# Altium schematic sheet GATE_DRIVER.SchDoc(GATE_DRIVER)
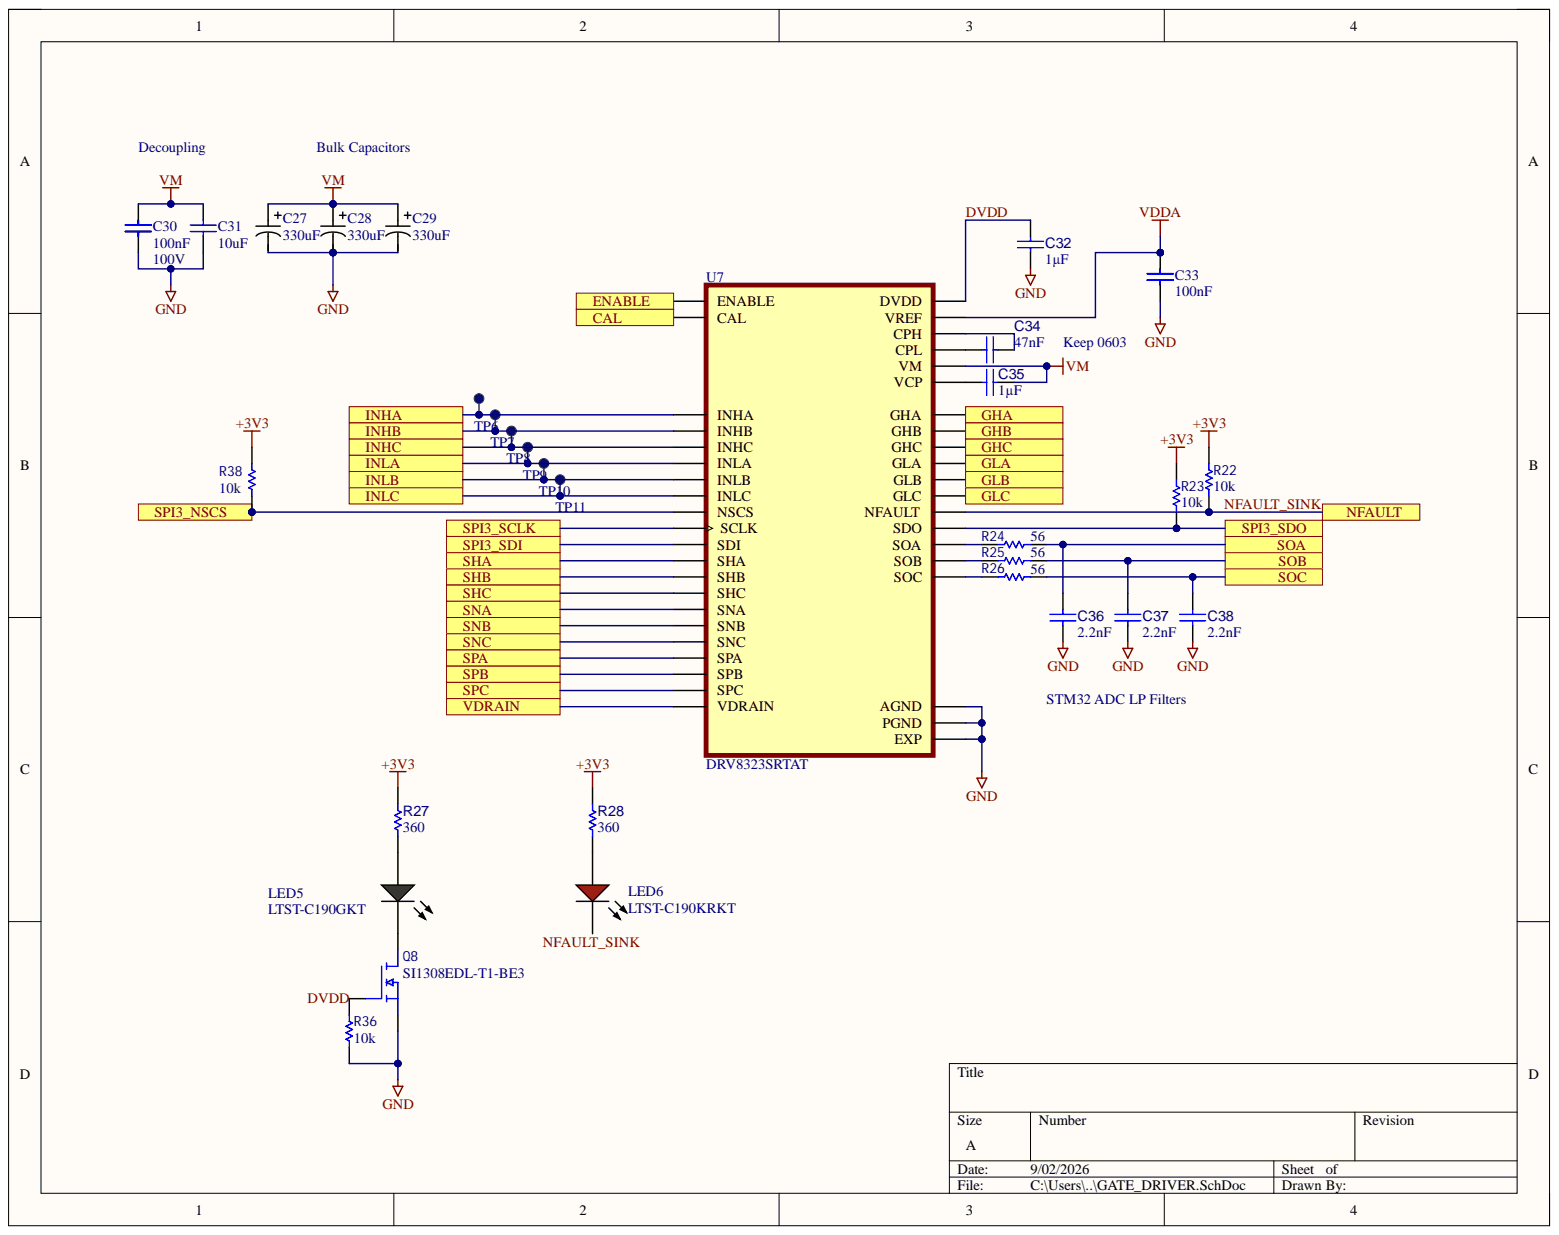
[diagram p0: GATE_DRIVER.SchDoc]

## Parts
C27  330uF  [CAP_PY-13x20_KEM]
C28  330uF  [CAP_PY-13x20_KEM]
C29  330uF  [CAP_PY-13x20_KEM]
C30  100nF  Voltage Rating: 100V  [0603_Capacitor]
C31  10uF  [CAP_CL32B_SAM]
C32  1µF  [0603_Capacitor]
C33  100nF  [C-0402]
C34  47nF  [0603_Capacitor]
C35  1µF  [0603_Capacitor]
C36  2.2nF  [C-0402]
C37  2.2nF  [C-0402]
C38  2.2nF  [C-0402]
LED5  LTST-C190GKT  [LTST-C190GKT_V]
LED6  LTST-C190KRKT  [LTST-C191KRKT_V]
Q8  value SI1308EDL-T1-BE3  Manufacturer PN: SI1308EDL-T1-BE3  [SC70_AOS]  (hidden comment: Transistor MOSFET SI1308EDL-T1-BE3)
R22  value 10k  [R-0402]  (hidden comment: Resistor 10k)
R23  value 10k  [R-0402]  (hidden comment: Resistor 10k)
R24  value 56  [R-0402]  (hidden comment: Resistor 56)
R25  value 56  [R-0402]  (hidden comment: Resistor 56)
R26  value 56  [R-0402]  (hidden comment: Resistor 56)
R27  360  [R-0402]
R28  360  [R-0402]
R36  value 10k  [R-0402]  (hidden comment: Resistor 10k)
R38  value 10k  [R-0402]  (hidden comment: Resistor 10k)
TP6  Test Pad  [Test_Pad]  (value not drawn)  (no BOM)
TP7  Test Pad  [Test_Pad]  (value not drawn)  (no BOM)
TP8  Test Pad  [Test_Pad]  (value not drawn)  (no BOM)
TP9  Test Pad  [Test_Pad]  (value not drawn)  (no BOM)
TP10  Test Pad  [Test_Pad]  (value not drawn)  (no BOM)
TP11  Test Pad  [Test_Pad]  (value not drawn)  (no BOM)
U7  DRV8323SRTAT  [QFN50P600X600X80-41N415X415]

## Ports
CAL, ENABLE, GHA, GHB, GHC, GLA, GLB, GLC, INHA, INHB, INHC, INLA, INLB, INLC, NFAULT, SHA, SHB, SHC, SNA, SNB, SNC, SOA, SOB, SOC, SPA, SPB, SPC, SPI3_NSCS, SPI3_SCLK, SPI3_SDI, SPI3_SDO, VDRAIN

## Nets
+3V3: R22.2 R23.2 R27.2 R28.2 R38.1
GND: C27.2 C28.2 C29.2 C30.2 C31.1 C32.2 C33.2 C36.1 C37.1 C38.1 Q8.2 R36.2 U7.32(AGND) U7.40(PGND) U7.41(EXP)
VDDA: C33.1 U7.24(VREF)
VM: C27.1 C28.1 C29.1 C30.1 C31.2 C35.2 U7.4(VM)
CAL: U7.31(CAL) ; port CAL
DVDD: C32.1 Q8.1 R36.1 U7.33(DVDD)
ENABLE: U7.30(ENABLE) ; port ENABLE
GHA: U7.6(GHA) ; port GHA
GHB: U7.15(GHB) ; port GHB
GHC: U7.16(GHC) ; port GHC
GLA: U7.8(GLA) ; port GLA
GLB: U7.13(GLB) ; port GLB
GLC: U7.18(GLC) ; port GLC
INHA: TP6.TP? U7.34(INHA) ; port INHA
INHB: TP7.TP? U7.36(INHB) ; port INHB
INHC: TP8.TP? U7.38(INHC) ; port INHC
INLA: TP9.TP? U7.35(INLA) ; port INLA
INLB: TP10.TP? U7.37(INLB) ; port INLB
INLC: TP11.TP? U7.39(INLC) ; port INLC
NFAULT_SINK: LED6.2 R22.1 U7.25(NFAULT) ; port NFAULT
SHA: U7.7(SHA) ; port SHA
SHB: U7.14(SHB) ; port SHB
SHC: U7.17(SHC) ; port SHC
SNA: U7.10(SNA) ; port SNA
SNB: U7.11(SNB) ; port SNB
SNC: U7.20(SNC) ; port SNC
SOA: C36.2 R24.2 ; port SOA
SOB: C37.2 R25.2 ; port SOB
SOC: C38.2 R26.2 ; port SOC
SPA: U7.9(SPA) ; port SPA
SPB: U7.12(SPB) ; port SPB
SPC: U7.19(SPC) ; port SPC
SPI3_NSCS: R38.2 U7.29(NSCS) ; port SPI3_NSCS
SPI3_SCLK: U7.28(SCLK) ; port SPI3_SCLK
SPI3_SDI: U7.27(SDI) ; port SPI3_SDI
SPI3_SDO: R23.1 U7.26(SDO) ; port SPI3_SDO
VDRAIN: U7.5(VDRAIN) ; port VDRAIN
NetC34_1: C34.1 U7.1(CPL)
NetC34_2: C34.2 U7.2(CPH)
NetC35_1: C35.1 U7.3(VCP)
NetLED5_1: LED5.1 R27.1
NetLED5_2: LED5.2 Q8.3
NetLED6_1: LED6.1 R28.1
NetR24_1: R24.1 U7.23(SOA)
NetR25_1: R25.1 U7.22(SOB)
NetR26_1: R26.1 U7.21(SOC)

## Library data of the parts
C27-C29 = CMP-001-00244-2: 330 µF 63 V Aluminum - Polymer Capacitors Radial, Can 42mOhm 2000 Hrs @ 125°C; Manufacturer: KEMET; Manufacturer PN: A759PY337M1JAAE042; ESR: 42m; Tolerance: 20%; Type: Capacitor Aluminum Polymer; Voltage Rating: 63V; Component Kind: Standard; ComponentLink1Description: Datasheet; ComponentLink1URL: https://yageogroup.com/content/datasheet/asset/file/KEM_A4072_A759; Library Name: BLDC_Symbols.SchLib; Package: TH; Supplier 1: Digikey; Supplier Part Number 1: 399-16573-ND; Value: 330uF
C30 = CAP, SMD, 0.1uF 0603 100V X7R: 0.1 µF ±10% 100V Ceramic Capacitor X7R 0603 (1608 Metric); Manufacturer: Walsin Technology Corporation; Manufacturer PN: 0603B104K101CT; Component Kind: Standard; ComponentLink1Description: Datasheet; ComponentLink1URL: https://www.mouser.com/datasheet/3/508/1/KEM_C1002_X7R_SMD.pdf; Library Name: BLDC_Symbols.SCHLIB; Package: 0603; Supplier 1: Digikey; Supplier Part Number 1: 1292-1392-1-ND; Tolerance: 10%; Type: Capacitor X7R; Value: 100nF
C31 = CMP-001-00241-2: 10 µF ±10% 63V Ceramic Capacitor X7R 1210 (3225 Metric); Manufacturer: Samsung Electro-Mechanics; Manufacturer PN: CL32B106KMVNNWE; Component Kind: Standard; ComponentLink1Description: Datasheet; ComponentLink1URL: https://mm.digikey.com/Volume0/opasdata/d220001/medias/docus/2124/CL32B106KMVNNWE_Spec.pdf; Library Name: BLDC_Symbols.SchLib; Package: 1210; Supplier 1: Digikey; Supplier Part Number 1: 1276-7053-1-ND; Tolerance: 10%; Type: Capacitor X7R; Value: 10uF; Voltage Rating: 63V
C32 C35 = CMP-001-00209-4: 1 µF ±10% 25V Ceramic Capacitor X7R 0603 (1608 Metric); Manufacturer: Samsung Electro-Mechanics; Manufacturer PN: CL10B105KA8NNNC; Component Kind: Standard; ComponentLink1Description: Datasheet; ComponentLink1URL: https://mm.digikey.com/Volume0/opasdata/d220001/medias/docus/3644/CL10B105KA8NNNC_spec.pdf; Library Name: BLDC_Symbols.SchLib; Package: 0603; Supplier 1: Digikey; Supplier Part Number 1: 1276-1184-1-ND; Tolerance: 10%; Type: Capacitor X7R; Value: 1µF; Voltage Rating: 25V
C33 = CMP-001-00203-1: 0.1 µF ±10% 50V Ceramic Capacitor X7R 0402 (1005 Metric); Manufacturer: Murata; Manufacturer PN: GCM155R71H104KE02J; Voltage Rating: 50; Component Kind: Standard; ComponentLink1Description: Datasheet; ComponentLink1URL: https://www.mouser.com/datasheet/3/508/1/KEM_C1002_X7R_SMD.pdf; Library Name: BLDC_Symbols.SCHLIB; Package: 0402; Supplier 1: Digikey; Supplier Part Number 1: GCM155R71H104KE02J; Tolerance: 10%; Type: Capacitor X7R; Value: 100nF
C34 = CMP-001-00242-1: 0603 C 47NF Ceramic Multilayer Capacitor, 100 V, +125DEGC, X7R Dielec, +/-10%; Manufacturer: KEMET; Manufacturer PN: C0603C473K1RACTU; Tolerance: 10%; Type: Capacitor X7R; Voltage Rating: 100V; Component Kind: Standard; ComponentLink1Description: Datasheet; ComponentLink1URL: https://yageogroup.com/content/datasheet/asset/file/KEM_C1002_X7R_SMD; Library Name: BLDC_Symbols.SchLib; Package: 0603; Supplier 1: Digikey; Supplier Part Number 1: 399-C0603C473K1RACTUCT-ND; Value: 47nF
C36-C38 = CMP-001-00243-1: Ceramic Capacitor, Multilayer, Ceramic, 25V, 10% +Tol, 10% -Tol, C0G, 30ppm/Cel TC, 0.0022uF, Surface Mount, 0402; Manufacturer: KEMET; Manufacturer PN: C0402C222F3GECTU; Tolerance: 1%; Type: Capacitor C0G/NP0; Component Kind: Standard; ComponentLink1Description: Datasheet; ComponentLink1URL: https://search.murata.co.jp/Ceramy/image/img/A01X/G101/ENG/GRM188R71C222KA01-01.pdf; Library Name: BLDC_Symbols.SchLib; Package: 0402; Supplier 1: Digikey; Supplier Part Number 1: 399-C0402C222F3GECTUCT-ND; Value: 2.2nF; Voltage Rating: 25V
LED5 = CMP-007-00022-2: LED Uni-Color Green, 100 mW, 30 mA, -55 to 85 degC, 2-Pin SMD, RoHS, Tape and Reel; Manufacturer: Lite-On Inc.; Current: 30mA; Power: 100mW; Component Kind: Standard; ComponentLink1Description: Datasheet; ComponentLink1URL: https://mm.digikey.com/Volume0/opasdata/d220001/medias/docus/858/LTST-C190GKT.pdf; Library Name: BLDC_Symbols.SchLib; Manufacturer PN: LTST-C190GKT; Package: 0603; Supplier 1: Digikey; Supplier Part Number 1: 160-LTST-C190GKTCT-ND; Type: LED; Value: LTST-C190GKT
LED6 = CMP-007-00024-2: LED Uni-Color Red, 62.5 mW, 25 mA, -30 to 85 degC, 2-Pin SMD, RoHS, Tape and Reel; Manufacturer: Lite-On Inc.; Current: 25mA; Power: 62.5mW; Component Kind: Standard; ComponentLink1Description: Datasheet; ComponentLink1URL: https://optoelectronics.liteon.com/upload/download/DS-22-99-0151/LTST-C190KRKT.pdf; Library Name: BLDC_Symbols.SchLib; Manufacturer PN: LTST-C190KRKT; Package: 0603; Supplier 1: Digikey; Supplier Part Number 1: 160-1436-1-ND; Type: LED; Value: LTST-C190KRKT
Q8 = Transistor MOSFET SI1308EDL-T1-BE3: N-Channel 30 V 1.4A (Tc) 400mW (Ta), 500mW (Tc) Surface Mount SC-70-3; Manufacturer: Vishay Siliconix; Current: 1.4; DCR: 132m; Power: 400W; Voltage Rating: 30; Component Kind: Standard; ComponentLink1Description: Datasheet; Library Name: BLDC_Symbols.SchLib; Package: SOT-323; Supplier 1: Digikey; Supplier Part Number 1: 742-SI1308EDL-T1-BE3CT-ND; Type: Transistor MOSFET
R22 R23 R36 R38 = Resistor 10k: 10 kOhms ±5% 0.063W, 1/16W Chip Resistor 0402 (1005 Metric) Moisture Resistant Thick Film; Manufacturer: YAGEO; Manufacturer PN: RC0402JR-0710KL; Power: 0.0625; Component Kind: Standard; ComponentLink1Description: Datasheet; Library Name: BLDC_Symbols.SchLib; Package: 0402; Supplier 1: Digikey; Supplier Part Number 1: 311-10KJRCT-ND; Tolerance: 5%; Type: Resistor
R24-R26 = Resistor 56: 56 Ohms ±5% 0.125W, 1/8W Chip Resistor 0402 (1005 Metric) Automotive AEC-Q200, Moisture Resistant Thick Film; Manufacturer: YAGEO; Manufacturer PN: AC0402JR-7W56RL; Power: 0.125; Component Kind: Standard; ComponentLink1Description: Datasheet; Library Name: BLDC_Symbols.SchLib; Package: 0402; Supplier 1: Digikey; Supplier Part Number 1: 13-AC0402JR-7W56RLCT-ND; Tolerance: 5%; Type: Resistor
R27 R28 = RES, 360 OHM 1% 1/16W 0402: 360 Ohms ±1% 0.063W, 1/16W Chip Resistor 0402 (1005 Metric) Moisture Resistant Thick Film; Manufacturer: YAGEO; Manufacturer PN: RC0402FR-13360RL; Power: 0.1W; Component Kind: Standard; ComponentLink1Description: Datasheet; Library Name: BLDC_Symbols.SCHLIB; Package: 0402; Supplier 1: Digikey; Supplier Part Number 1: 13-RC0402FR-13360RLCT-ND; Tolerance: 1%; Type: Resistor; Value: 360
TP6-TP11 = CMP-00030-00017-2: Small Test Pad for Testing - Natsu; ComponentLink1Description: Datasheet; Library Name: BLDC_Symbols.SCHLIB; Type: Test Pad; Value: Test Pad
U7 = CMP-014-00013-1: 65-V max 3-phase smart gate driver with current shunt amplifiers; Manufacturer: Texas Instruments; Tolerance: QFN; Component Kind: Standard; ComponentLink1Description: Datasheet; ComponentLink1URL: https://www.ti.com/general/docs/suppproductinfo.tsp?distId=10&gotoUrl=https%3A%2F%2Fwww.ti.com%2Flit%2Fgpn%2Fdrv8323; Library Name: BLDC_Symbols.SchLib; Manufacturer PN: DRV8323SRTAT; Package: QFN; Supplier 1: Digikey; Supplier Part Number 1: 296-47095-1-ND; Type: Gate Driver; Value: DRV8323SRTAT
